# Altium schematic sheet 02 Mobile Power.SchDoc
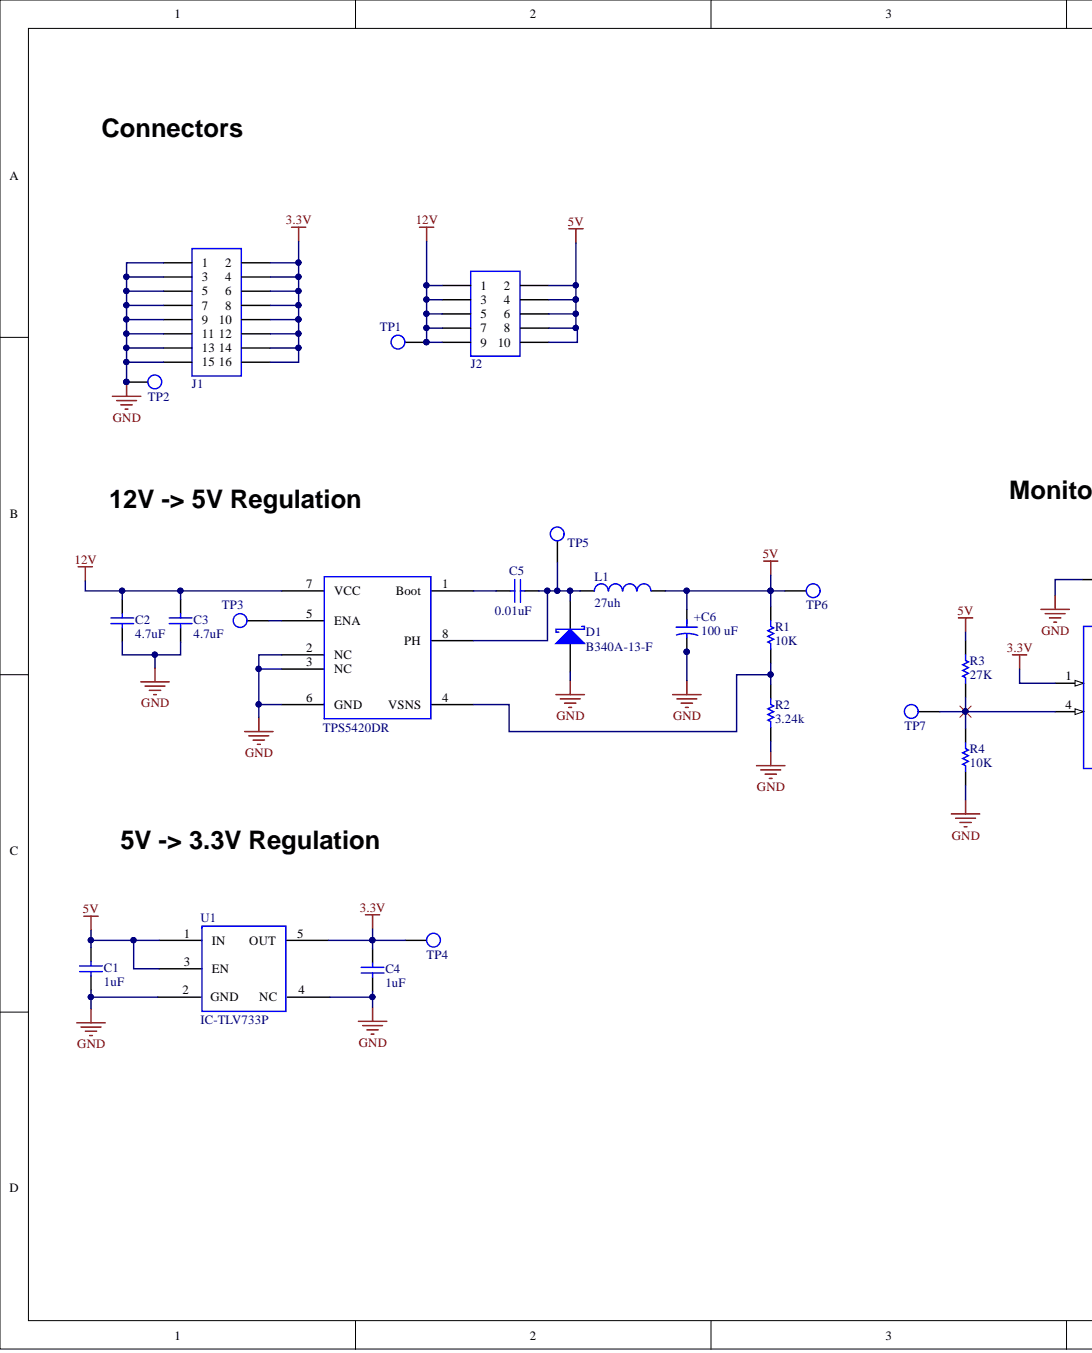
[diagram p0: 02 Mobile Power.SchDoc, left]
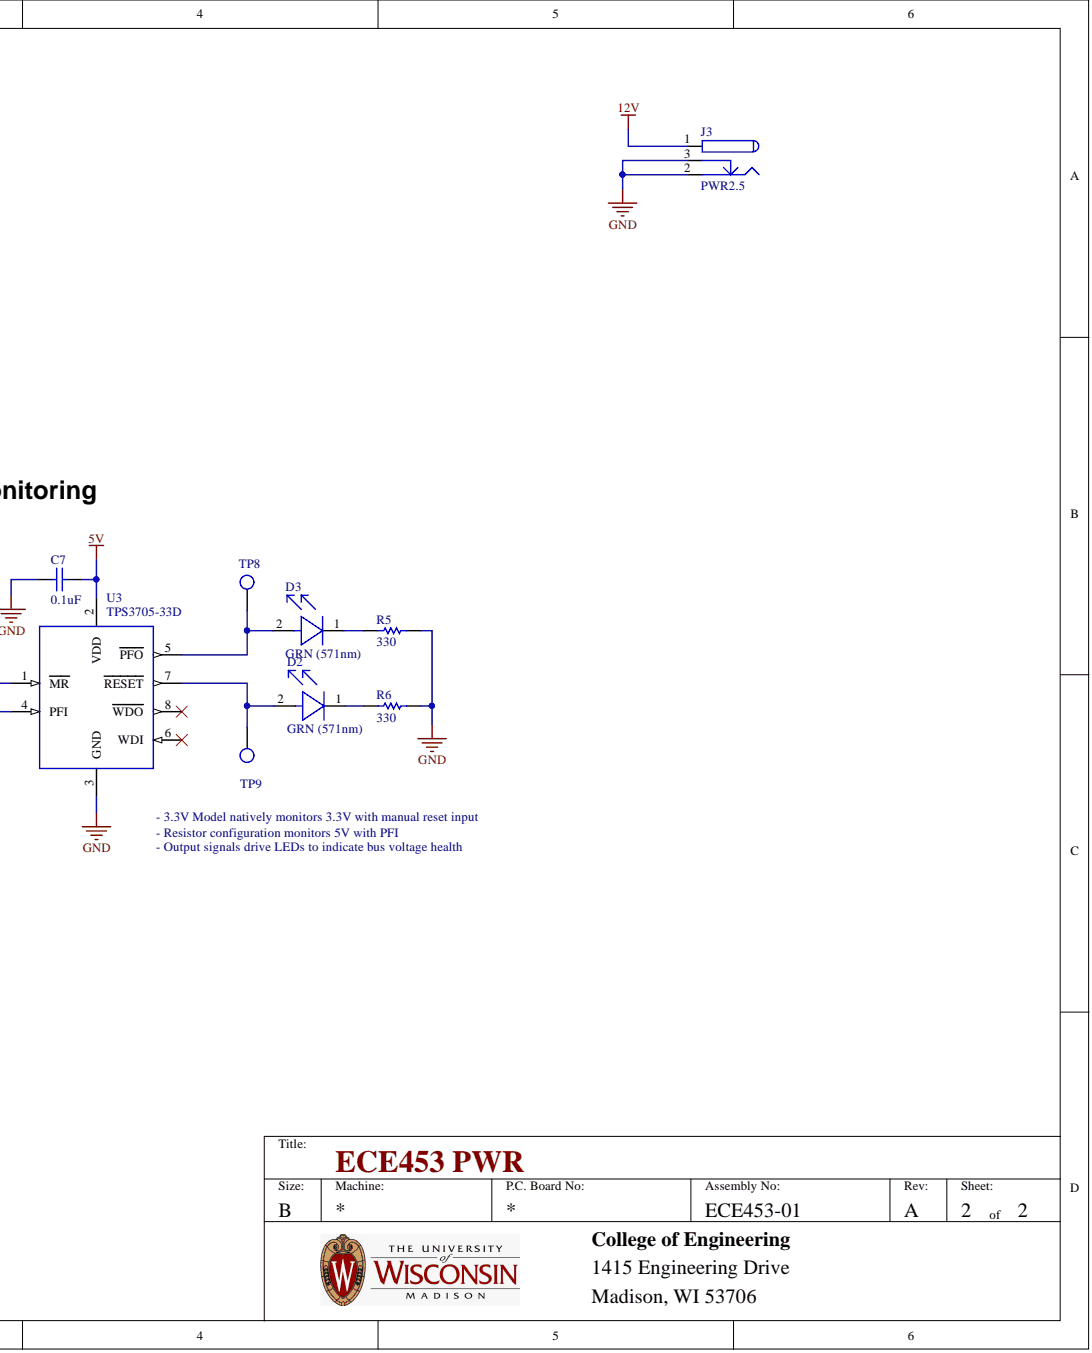
[diagram p1: 02 Mobile Power.SchDoc, right]

## Parts
C1  1uF  [CAP_0805]
C2  4.7uF  [CAP_0805]
C3  4.7uF  [CAP_0805]
C4  1uF  [CAP_0805]
C5  0.01uF  [CAP_0805]
C6  100 uF  [CAP_100uF]
C7  0.1uF  [CAP_0805]
D1  Manufacturer Part Number: B340A-13-F  [B340A]  (hidden comment: DIODE_B340A)
D2  value GRN (571nm)  [DIODE_0805]  (hidden comment: LED_GREEN_0805.1)
D3  value GRN (571nm)  [DIODE_0805]  (hidden comment: LED_GREEN_0805.1)
J1  CONN_HEADER_MALE_8x2  [SBH11-PBPC-D08-ST-BK]  (value not drawn)
J2  CONN_HEADER_MALE_5x2  [SBH11-PBPC-D05-ST-BK]  (value not drawn)
J3  PWR2.5  [PJ-102]
L1  Inductance: 27uh  [IND-ASPI-8040S]  (hidden comment: IND_8040S_270M)
R1  10K  [RES_0805]
R2  Resistance: 3.24k  [RES_0805]  (hidden comment: RES_0805_K_003.34)
R3  27K  [RES_0805]
R4  10K  [RES_0805]
R5  330  [RES_0805]
R6  330  [RES_0805]
TP1  TP_1206  [TP-SMC-1206]  (value not drawn)
TP2  TP_1206  [TP-SMC-1206]  (value not drawn)
TP3  TP_1206  [TP-SMC-1206]  (value not drawn)
TP4  TP_1206  [TP-SMC-1206]  (value not drawn)
TP5  TP_1206  [TP-SMC-1206]  (value not drawn)
TP6  TP_1206  [TP-SMC-1206]  (value not drawn)
TP7  TP_1206  [TP-SMC-1206]  (value not drawn)
TP8  TP_1206  [TP-SMC-1206]  (value not drawn)
TP9  TP_1206  [TP-SMC-1206]  (value not drawn)
U1  IC-TLV733P  [TI-SOT-23-5]
U2  Manufacturer Part Number: TPS5420DR  [TI-SOIC-8]  (hidden comment: IC_TPS5420)
U3  Manufacturer Part Number: TPS3705-33D  [TI-SOIC-8]  (hidden comment: IC_TPS3705-33DGN.1)

## Nets
3.3V: C4.2 J1.2 J1.4 J1.6 J1.8 J1.10 J1.12 J1.14 J1.16 TP4.1 U1.5(OUT) U3.1(MR)
5V: C1.2 C6.1 C7.2 J2.2 J2.4 J2.6 J2.8 J2.10 L1.2 R1.2 R3.2 TP6.1 U1.1(IN) U1.3(EN) U3.2(VDD)
12V: C2.2 C3.2 J2.1 J2.3 J2.5 J2.7 J2.9 J3.1 TP1.1 U2.7(VCC)
GND: C1.1 C2.1 C3.1 C4.1 C6.2 C7.1 D1.1 J1.1 J1.3 J1.5 J1.7 J1.9 J1.11 J1.13 J1.15 J3.2 J3.3 R2.1 R4.1 R5.2 R6.2 TP2.1 U1.2(GND) U1.4(NC) U2.2(NC) U2.3(NC) U2.6(GND) U3.3(GND)
NetC5_1: C5.1 U2.1(Boot)
NetC5_2: C5.2 D1.2 L1.1 TP5.1 U2.8(PH)
NetD2_1: D2.1 R6.1
NetD2_2: D2.2 TP9.1 U3.7(RESET)
NetD3_1: D3.1 R5.1
NetD3_2: D3.2 TP8.1 U3.5(PFO)
NetR1_1: R1.1 R2.2 U2.4(VSNS)
NetR3_1: R3.1 R4.2 TP7.1 U3.4(PFI)
NetTP3_1: TP3.1 U2.5(ENA)

Not connected: U3 6(WDI) 8(WDO)

## Library data of the parts
C1 C4 = CAP_0805_U_001.00: CAP CER 1 UF 6.3V 10% X5R 0805; Tolerance: +/-10%; Value: 10UF; Component Kind: Standard; Library Name: ECE453_PWR.SchLib; Package: 0805; Rating: 6.3V; Supplier 1: Digi-Key; Supplier Part Number 1: 399-9160-1-ND
C2 C3 = CAP_0805_U_004.70: CAP CER 4.7 UF 16V 10% X5R 0805; Tolerance: +/-10%; Component Kind: Standard; Library Name: ECE453_PWR.SchLib; Package: 0805; Rating: 16V; Supplier 1: Digi-Key; Supplier Part Number 1: 478-5166-6-ND; Value: 4.7UF
C5 = CAP_0805_U_000.01: CAP CER 10000PF 16V 10% X7R 0805; Tolerance: +/-10%; Component Kind: Standard; Library Name: ECE453_PWR.SchLib; Package: 0805; Rating: 16V; Supplier 1: Digi-Key; Supplier Part Number 1: 399-7995-1-ND; Value: 10000PF
C6 = CAP_100uF: Manufacturer: Nichicon; Manufacturer Part Number: UCD1V101MNL1GS; Capacitance: 100µF; Impedance: 160 mOhm; Lifetime @ Temp.: 5000 Hrs @ 105°C; Ripple Current: 600mA; Surface Mount Land Size: 0.327" L x 0.327" W (8.30mm x 8.30mm); Tolerance: ±20%; Voltage Rating: 35V; Applications: General Purpose; Category: Capacitors; Component Kind: Standard; ComponentLink1Description: http://nichicon-us.com/english/products/pdfs/e-cd.pdf; ComponentLink1URL: http://nichicon-us.com/english/products/pdfs/e-cd.pdf; ESR (Equivalent Series Resistance): -; Height - Seated (Max): 0.413" (10.50mm); Lead Spacing: -; Library Name: ECE453_PWR.SchLib; Mounting Type: Surface Mount; Operating Temperature: -55°C ~ 105°C; Package / Case: Radial, Can - SMD; Packaging: Cut Tape (CT); Pricing 1: 1=0.57, 10=0.548, 25=0.3884, 50=0.3272, 100=0.2659, 250=0.22088 (USD); RoHS: RoHS Compliant; Size / Dimension: 0.315" Dia (8.00mm); Stock 1: 2915; Supplier 1: Digi-Key; Supplier Part Number 1: 493-6186-1-ND; Type: -
C7 = CAP_0805_U_000.10: CAP CER 0.1 UF 6.3V 10% X7R 0805; Tolerance: +/-10%; Value: 1UF; Component Kind: Standard; Library Name: ECE453_PWR.SchLib; Package: 0805; Rating: 6.3V; Supplier 1: Digi-Key; Supplier Part Number 1: 399-9155-6-ND
D1 = DIODE_B340A: DIODE SCHOTTKY 40V 3A SMA; Manufacturer: Diodes; Capacitance: 250pF; Element Configuration: Single; Forward Voltage: 500mV; Max Forward Surge Current (Ifsm): 80A; Peak Reverse Current: 500uA; Polarity: Standard; Average Rectified Current: 3A; Case/Package: SMA; Component Kind: Standard; ComponentLink1Description: http://www.mccsemi.com/up_pdf/MBRX120-MBRX160(SOD123).pdf; ComponentLink1URL: http://www.mccsemi.com/up_pdf/MBRX120-MBRX160(SOD123).pdf; ComponentLink2Description: https://datasheet.ciiva.com/4245/861ds30891-4245763.pdf; ComponentLink2URL: https://datasheet.ciiva.com/4245/861ds30891-4245763.pdf; ComponentLink3Description: https://datasheet.ciiva.com/1177/ds30891-1177476.pdf; ComponentLink3URL: https://datasheet.ciiva.com/1177/ds30891-1177476.pdf; ComponentLink4Description: https://datasheet.ciiva.com/1240/ds30891-1240228.pdf; ComponentLink4URL: https://datasheet.ciiva.com/1240/ds30891-1240228.pdf; ComponentLink5Description: https://datasheet.ciiva.com/913/ds30891-913637.pdf; ComponentLink5URL: https://datasheet.ciiva.com/913/ds30891-913637.pdf; ComponentLink6Description: https://datasheet.ciiva.com/913/ds30891-913638.pdf; ComponentLink6URL: https://datasheet.ciiva.com/913/ds30891-913638.pdf; ComponentLink7Description: https://datasheet.ciiva.com/913/ds30891-913639.pdf; ComponentLink7URL: https://datasheet.ciiva.com/913/ds30891-913639.pdf; ComponentLink8Description: https://datasheet.ciiva.com/26949/getdatasheetpartid-143394-26949352.pdf; ComponentLink8URL: https://datasheet.ciiva.com/26949/getdatasheetpartid-143394-26949352.pdf; ComponentLink9Description: https://datasheet.ciiva.com/46393/1911813-46393773.pdf; ComponentLink9URL: https://datasheet.ciiva.com/46393/1911813-46393773.pdf; Contact Plating: Tin,Matte; Current: 3A; Current Rating: 3A; Forward Current: 3A; Height: 2.3mm; Lead Free: Lead Free; Length: 4.6mm; Library Name: ECE453_PWR.SchLib; Max Operating Temperature: 125°C; Max Repetitive Reverse Voltage (Vrrm): 40V; Max Reverse Voltage (DC): 40V; Min Operating Temperature: -55°C; Mount: Surface Mount; Number of Pins: 2; Output Current: 3A; Package Quantity: 5000; Packaging: Tape and Reel; Peak Non-Repetitive Surge Current: 80A; Pricing 1: 1=0.46, 10=0.373, 100=0.2538, 500=0.19034, 1000=0.14276 (USD); Radiation Hardening: No; REACH SVHC: No SVHC; Stock 1: 590182; Supplier 1: Digi-Key; Supplier Part Number 1: B340A-FDICT-ND; Termination: SMD/SMT; Voltage: 40V; Voltage Rating (DC): 40V; Weight: 0.002258oz; Width: 2.92mm
D2 D3 = LED_GREEN_0805.1: LED GREEN CLEAR THIN 0805 SMD; Rating: 20mA; Component Kind: Standard; Library Name: ECE453_PWR.SchLib; Package: 0805; Supplier 1: Digi-Key; Supplier Part Number 1: 160-1426-1-ND; Tolerance: N/A
J1 = CONN_HEADER_MALE_8x2: CONN HEADER 2.54MM 16POS GOLD; Manufacturer: Sullins Connector Solutions; Connector Type: Header, Shrouded; Number of Positions: 16; Number of Positions Loaded: All; Pitch: 0.100" (2.54mm); Category: Connectors, Interconnects; Color: Black; Component Kind: Standard; ComponentLink1Description: http://sullinscorp.com/catalogs/145_PAGE118_.100_SBH11_SERIES_MALE_BOX_HDR_ST_RA_SMT.pdf; ComponentLink1URL: http://sullinscorp.com/catalogs/145_PAGE118_.100_SBH11_SERIES_MALE_BOX_HDR_ST_RA_SMT.pdf; Contact Finish: Gold; Contact Finish Thickness: Flash; Contact Mating Length: -; Contact Type: Male Pin; Fastening Type: -; Features: Polarizing Key; Library Name: ECE453_PWR.SchLib; Manufacturer Part Number: SBH11-PBPC-D08-ST-BK; Mounting Type: Through Hole; Number of Rows: 2; Packaging: Tray; Part Status: Active; Pricing 1: 1=0.55, 10=0.428, 25=0.3988, 50=0.3626, 100=0.3263, 250=0.27552, 500=0.261, 1000=0.232, 2500=0.19285 (USD); RoHS: RoHS Compliant; Row Spacing: 0.100" (2.54mm); Stock 1: 13784; Supplier 1: Digi-Key; Supplier Part Number 1: S9171-ND; Termination: Solder
J2 = CONN_HEADER_MALE_5x2: CONN HEADER 2.54MM 10POS GOLD; Manufacturer: Sullins Connector Solutions; Connector Type: Header, Shrouded; Number of Positions: 10; Number of Positions Loaded: All; Pitch: 0.100" (2.54mm); Category: Connectors, Interconnects; Color: Black; Component Kind: Standard; ComponentLink1Description: http://sullinscorp.com/catalogs/145_PAGE118_.100_SBH11_SERIES_MALE_BOX_HDR_ST_RA_SMT.pdf; ComponentLink1URL: http://sullinscorp.com/catalogs/145_PAGE118_.100_SBH11_SERIES_MALE_BOX_HDR_ST_RA_SMT.pdf; Contact Finish: Gold; Contact Finish Thickness: Flash; Contact Mating Length: -; Contact Type: Male Pin; Fastening Type: -; Features: Polarizing Key; Library Name: ECE453_PWR.SchLib; Manufacturer Part Number: SBH11-PBPC-D05-ST-BK; Mounting Type: Through Hole; Number of Rows: 2; Packaging: Tray; Part Status: Active; Pricing 1: 1=0.64, 10=0.445, 25=0.3812, 50=0.343, 100=0.2985, 250=0.254, 500=0.2413, 1000=0.2159, 2500=0.17145 (USD); RoHS: RoHS Compliant; Row Spacing: 0.100" (2.54mm); Stock 1: 29227; Supplier 1: Digi-Key; Supplier Part Number 1: S9169-ND; Termination: Solder
J3 = PWR2.5: Low Voltage Power Supply Connector; Manufacturer: CUI; Manufacturer Part Number: PJ-102A; Connector Type: Jack; Current Rating: 2.5A; Gender: Male; Insulation Resistance: 50MR; Number of Conductors: 2; Shielding: Unshielded; Voltage Rating: 24V; Colour: Black; Component Kind: Standard; ComponentLink1Description: Manufacturer Link; ComponentLink1URL: http://domino.kycon.com/internet/Products.html; ComponentLink2Description: http://www.cui.com/Product/Resource/FuturePDF/PJ-102A.pdf; ComponentLink2URL: http://www.cui.com/Product/Resource/FuturePDF/PJ-102A.pdf; ComponentLink3Description: http://www.mouser.com/ds/2/670/PJ-102A-524195.pdf; ComponentLink3URL: http://www.mouser.com/ds/2/670/PJ-102A-524195.pdf; ComponentLink4Description: https://www.cui.com/product/resource/digikeypdf/pj-102a.pdf; ComponentLink4URL: https://www.cui.com/product/resource/digikeypdf/pj-102a.pdf; Contact Gender: Male; Contact Material: Copper; Contact Plating: Nickel; DatasheetDocument: 09-May-2002; Diameter: 2.1mm; Flammability Rating: UL94 V-0; Housing Colour: Black; Housing Material: Thermoplastic; Lead Free: Lead Free; Library Name: ECE453_PWR.SchLib; Max Operating Temperature: 85°C; Min Operating Temperature: -25°C; Mount: Through Hole; Orientation: Horizontal; Package Quantity: 280; Packaging: Bulk; Pricing 1: 1=0.64, 10=0.6, 25=0.54, 50=0.48, 100=0.46, 250=0.42, 500=0.4, 1000=0.33, 2500=0.3 (USD); Published: 17-Dec-2003; Stock 1: 20997; Supplier 1: Digi-Key; Supplier Part Number 1: CP-102A-ND; Termination: Through Hole; Publisher: Altium Limited
L1 = IND_8040S_270M: Manufacturer: Abracon; Manufacturer Part Number: ASPI-8040S-270M-T; Core Material: Ferrite; Current Rating: 2A; DC Resistance (DCR): 78mR; Saturation Current: 2.15A; Self Resonant Frequency: 9.2MHz; Shielding: Shielded; Test Frequency: 100kHz; Tolerance: 20%; Case/Package: Nonstandard; Component Kind: Standard; ComponentLink1Description: http://www.abracon.com/Magnetics/chips/AIML-1206.pdf; ComponentLink1URL: http://www.abracon.com/Magnetics/chips/AIML-1206.pdf; ComponentLink2Description: https://datasheet.ciiva.com/8518/aspi-8040s-8518746.pdf; ComponentLink2URL: https://datasheet.ciiva.com/8518/aspi-8040s-8518746.pdf; ComponentLink3Description: https://datasheet.ciiva.com/1080/aspi-8040s-1080475.pdf; ComponentLink3URL: https://datasheet.ciiva.com/1080/aspi-8040s-1080475.pdf; ComponentLink4Description: https://datasheet.ciiva.com/1080/aspi-8040s-1080476.pdf; ComponentLink4URL: https://datasheet.ciiva.com/1080/aspi-8040s-1080476.pdf; Composition: Wirewound; Height: 4mm; Height - Seated (Max): 0.157inch; Lead Free: Lead Free; Length: 0.315inch; Library Name: ECE453_PWR.SchLib; Max DC Current: 2A; Max Operating Temperature: 125°C; Min Operating Temperature: -40°C; Mount: Surface Mount; Package Quantity: 1000; Packaging: Tape and Reel; Pricing 1: 1=0.97, 10=0.897, 100=0.6486, 500=0.4968 (USD); Stock 1: 2115; Supplier 1: Digi-Key; Supplier Part Number 1: ASPI-8040S-270M-TCT-ND; Width: 0.315inch
R1 R4 = RES_0805_K_010.00: RES SMD 10K OHM 1% 1/8W 0805; Manufacturer: Panasonic Electronic Components; Manufacturer Part Number: ERJ-6ENF1002V; Power (Watts): 0.125W, 1/8W; Temperature Coefficient: ±100ppm/°C; Category: Resistors; Component Kind: Standard; Composition: Thick Film; Failure Rate: -; Features: Automotive AEC-Q200; Height - Seated (Max): 0.028" (0.70mm); Library Name: ECE453_PWR.SchLib; Number of Terminations: 2; Operating Temperature: -55°C ~ 155°C; Package / Case: 0805 (2012 Metric); Packaging: Cut Tape (CT); Part Status: Active; Resistance (Ohms): 10k; RoHS: RoHS Compliant; Size / Dimension: 0.079" L x 0.049" W (2.00mm x 1.25mm); Supplier: Digi-Key; Supplier Device Package: 0805; Supplier Part Number: P10.0KCCT-ND; Tolerance: ±1%
R2 = RES_0805_K_003.34: RES SMD 3.24K OHM 1% 1/8W 0805; Manufacturer: Panasonic; Manufacturer Part Number: ERJ-6ENF3241V; Max Power Dissipation: 125mW; Temperature Coefficient: 100ppm/°C; Voltage Rating: 150V; Case/Package: 0805; Case Code (Imperial): 0805; Case Code (Metric): 2012; Component Kind: Standard; ComponentLink1Description: https://datasheet.ciiva.com/9196/aoa0000ce2-9196535.pdf; ComponentLink1URL: https://datasheet.ciiva.com/9196/aoa0000ce2-9196535.pdf; ComponentLink2Description: https://datasheet.ciiva.com/30112/aoa0000ce2-30112759.pdf; ComponentLink2URL: https://datasheet.ciiva.com/30112/aoa0000ce2-30112759.pdf; ComponentLink3Description: https://datasheet.ciiva.com/28531/1694929-28531704.pdf; ComponentLink3URL: https://datasheet.ciiva.com/28531/1694929-28531704.pdf; ComponentLink4Description: https://datasheet.ciiva.com/46372/1678782-46372873.pdf; ComponentLink4URL: https://datasheet.ciiva.com/46372/1678782-46372873.pdf; ComponentLink5Description: https://datasheet.ciiva.com/17213/aoa0000ce2-17213770.pdf; ComponentLink5URL: https://datasheet.ciiva.com/17213/aoa0000ce2-17213770.pdf; ComponentLink6Description: https://datasheet.ciiva.com/46372/1678782-46372874.pdf; ComponentLink6URL: https://datasheet.ciiva.com/46372/1678782-46372874.pdf; ComponentLink7Description: https://datasheet.ciiva.com/24026/aoa0000ce2-24026155.pdf; ComponentLink7URL: https://datasheet.ciiva.com/24026/aoa0000ce2-24026155.pdf; ComponentLink8Description: https://datasheet.ciiva.com/22668/1678782-22668189.pdf; ComponentLink8URL: https://datasheet.ciiva.com/22668/1678782-22668189.pdf; Composition: Thick Film; Contact Plating: Tin; Depth: 1.25mm; Height: 0.6mm; Lead Free: Lead Free; Length: 2mm; Library Name: ECE453_PWR.SchLib; Max Operating Temperature: 155°C; Military Standard: Not; Min Operating Temperature: -55°C; Mount: Surface Mount; Number of Terminations: 2; Package Quantity: 1; Packaging: Tape and Reel; Power Rating: 125mW; Pricing 1: 1=0.1, 10=0.079, 100=0.0305, 1000=0.0134, 2500=0.01157 (USD); Radiation Hardening: No; REACH SVHC: No SVHC; Stock 1: 232903; Supplier 1: Digi-Key; Supplier Part Number 1: P3.24KCCT-ND; Termination: SMD/SMT; Tolerance: 1%; Voltage Rating (DC): 150V; Width: 0.049inch
R3 = RES_0805_K_027.00: RES SMD 27.0K OHM 1% 1/8W 0805; Manufacturer: Panasonic Electronic Components; Manufacturer Part Number: ERJ-6ENF2802V; Power (Watts): 0.125W, 1/8W; Resistance (Ohms): 28k; Temperature Coefficient: ±100ppm/°C; Category: Resistors; Component Kind: Standard; Composition: Thick Film; Failure Rate: -; Features: Automotive AEC-Q200; Height - Seated (Max): 0.028" (0.70mm); Library Name: ECE453_PWR.SchLib; Number of Terminations: 2; Operating Temperature: -55°C ~ 155°C; Package / Case: 0805 (2012 Metric); Packaging: Cut Tape (CT); Part Status: Active; RoHS: RoHS Compliant; Size / Dimension: 0.079" L x 0.049" W (2.00mm x 1.25mm); Supplier: Digi-Key; Supplier 1: Digi-Key; Supplier Device Package: 0805; Supplier Part Number: P28.0KCCT-ND; Supplier Part Number 1: CR0805-FX-2702GLFDKR-ND; Tolerance: ±1%
R5 R6 = RES_0805_R_330.00: RES SMD 330 OHM 1% 1/8W 0805; Manufacturer: Panasonic Electronic Components; Manufacturer Part Number: ERJ-6GEYJ331V; Power (Watts): 0.125W, 1/8W; Temperature Coefficient: ±200ppm/°C; Tolerance: ±5%; Category: Resistors; Component Kind: Standard; Composition: Thick Film; Failure Rate: -; Features: Automotive AEC-Q200; Height - Seated (Max): 0.028" (0.70mm); Library Name: ECE453_PWR.SchLib; Number of Terminations: 2; Operating Temperature: -55°C ~ 155°C; Package / Case: 0805 (2012 Metric); Packaging: Cut Tape (CT); Part Status: Active; Resistance (Ohms): 330; RoHS: RoHS Compliant; Size / Dimension: 0.079" L x 0.049" W (2.00mm x 1.25mm); Supplier: Digi-Key; Supplier Device Package: 0805; Supplier Part Number: P330ACT-ND
TP1-TP9 = TP_1206: Test Point SMD 1206; Component Kind: Standard; Library Name: ECE453_PWR.SchLib; Supplier 1: Digi-Key; Supplier Part Number 1: A106143CT-ND
U1 = IC-TLV733P: IC REG LINEAR 3.3V 300MA SOT23-5; Component Kind: Standard; Library Name: ECE453_PWR.SchLib
U2 = IC_TPS5420: IC REG BUCK ADJ 2A 8SOIC; Manufacturer: Texas Instruments; Efficiency: 95%; Max Input Voltage: 36V; Max Output Voltage: 31V; Min Input Voltage: 5.5V; Min Output Voltage: 1.23V; Number of Outputs: 1; Quiescent Current: 3mA; Switching Frequency: 500kHz; Synchronous Rectifier: No; Case/Package: D; Component Kind: Standard; ComponentLink1Description: https://datasheet.ciiva.com/6596/tps5420-6596997.pdf; ComponentLink1URL: https://datasheet.ciiva.com/6596/tps5420-6596997.pdf; ComponentLink2Description: https://datasheet.ciiva.com/6596/tps5420-6596998.pdf; ComponentLink2URL: https://datasheet.ciiva.com/6596/tps5420-6596998.pdf; ComponentLink3Description: https://datasheet.ciiva.com/34302/1523971-34302343.pdf; ComponentLink3URL: https://datasheet.ciiva.com/34302/1523971-34302343.pdf; ComponentLink4Description: https://datasheet.ciiva.com/3220/tps5420-3220184.pdf; ComponentLink4URL: https://datasheet.ciiva.com/3220/tps5420-3220184.pdf; ComponentLink5Description: https://datasheet.ciiva.com/6580/tps5420-6580024.pdf; ComponentLink5URL: https://datasheet.ciiva.com/6580/tps5420-6580024.pdf; ComponentLink6Description: https://datasheet.ciiva.com/3220/tps5420-3220185.pdf; ComponentLink6URL: https://datasheet.ciiva.com/3220/tps5420-3220185.pdf; Lead Free: Lead Free; Library Name: ECE453_PWR.SchLib; Max Operating Temperature: 125°C; Max Output Current: 2A; Min Operating Temperature: -40°C; Number of Pins: 8; Operating Supply Voltage: 36V; Output Current: 2A; Output Voltage: 31V; Package Quantity: 2500; Packaging: Tape and Reel; Pricing 1: 1=3.24, 10=2.912, 100=2.3861, 500=2.03124, 1000=1.7131 (USD); Radiation Hardening: No; Stock 1: 17036; Supplier 1: Digi-Key; Supplier Part Number 1: 296-31984-1-ND; Weight: 0.002677oz
U3 = IC_TPS3705-33DGN.1: IC 2.93V PROCESSOR MONITOR 8MSOP; Manufacturer: Texas Instruments; Number of Voltages Monitored: 1; Output: Push-Pull, Totem Pole; Reset: Active Low; Reset Timeout: 140 ms Minimum; Category: Integrated Circuits (ICs); Component Kind: Standard; ComponentLink1Description: http://www.ti.com/lit/ds/symlink/tps3705-30.pdf; ComponentLink1URL: http://www.ti.com/lit/ds/symlink/tps3705-30.pdf; Library Name: ECE453_PWR.SchLib; Mounting Type: Surface Mount; Operating Temperature: -40°C ~ 85°C (TA); Package / Case: 8-SOIC (0.154", 3.90mm Width); Packaging: Tube; Part Status: Active; Pricing 1: 1=2.04, 10=1.831, 100=1.4718, 500=1.20926, 1000=1.00195, 2500=0.93285, 5000=0.8983, 10000=0.86375 (USD); RoHS: RoHS Compliant; Stock 1: 1844; Supplier 1: Digi-Key; Supplier Device Package: 8-SOIC; Supplier Part Number 1: 296-2623-5-ND; Type: Simple Reset/Power-On Reset; Voltage - Threshold: 2.93V
